# Altium schematic sheet lcd.SchDoc(lcd)
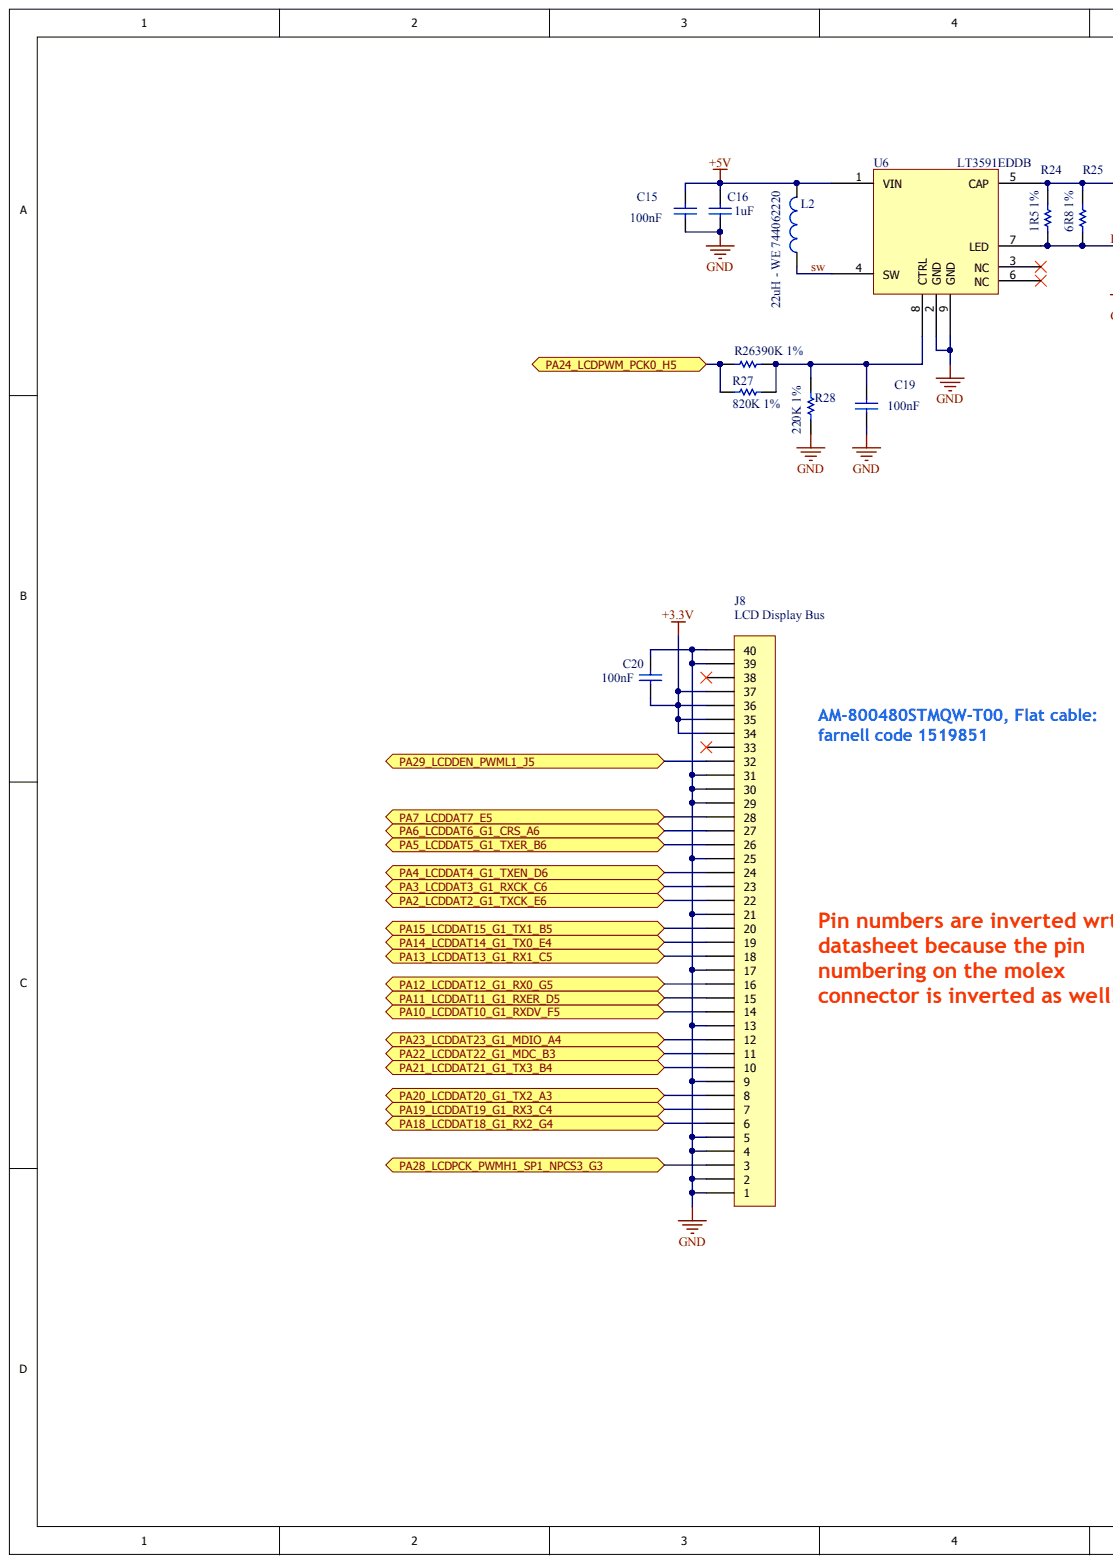
[diagram p0: lcd.SchDoc, left]
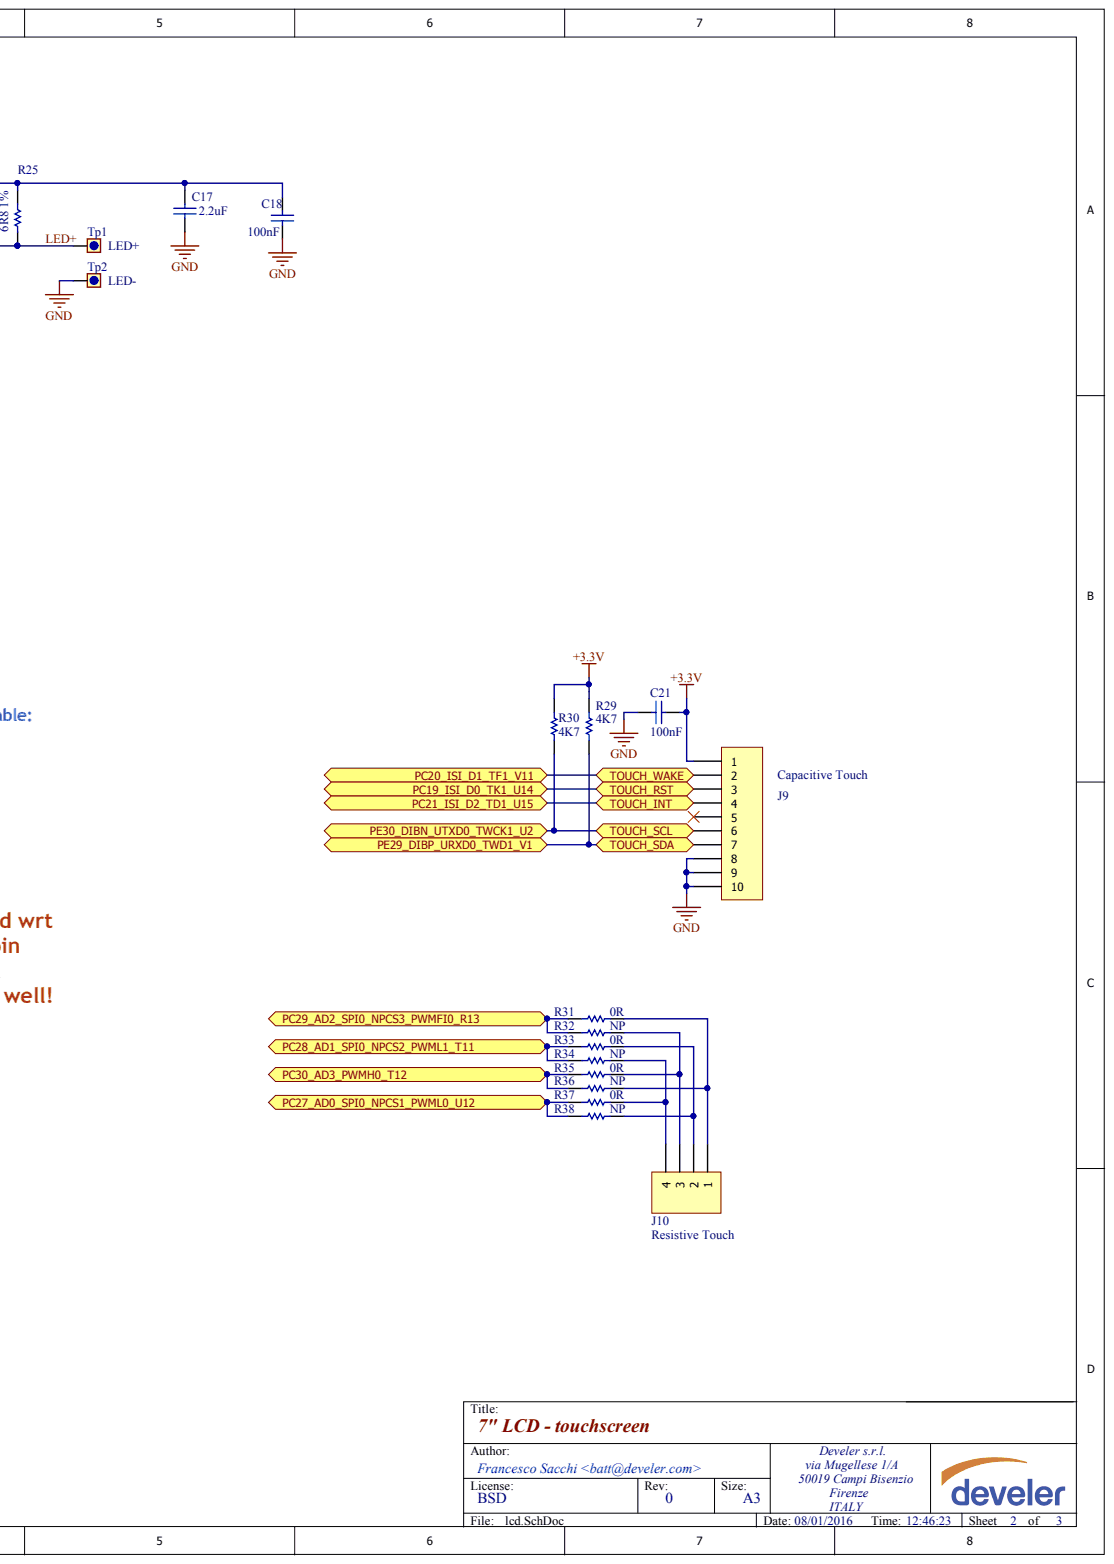
[diagram p1: lcd.SchDoc, right]

## Parts
C15  100nF  [0603_[1608]]
C16  1uF  [0603_[1608]]
C17  2.2uF  [1210_[3225]]
C18  100nF  [0603_[1608]]
C19  100nF  [0603_[1608]]
C20  100nF  [0603_[1608]]
C21  100nF  [0603_[1608]]
J8  LCD Display Bus  [fcc-zif_40pin_0.5mm]
J9  Capacitive Touch  [fcc-zif_10pin_0.5mm]
J10  Resistive Touch  [fcc-zif_4pin_1mm]
L2  22uH - WE 744062220  [WE-TPC-6823-X]
R24  1R5 1%  [0603_[1608]]
R25  6R8 1%  [0603_[1608]]
R26  390K 1%  [0603_[1608]]
R27  820K 1%  [0603_[1608]]
R28  220K 1%  [0603_[1608]]
R29  4K7  [0603_[1608]]
R30  4K7  [0603_[1608]]
R31  0R  [0603_[1608]]
R32  NP  [0603_[1608]]
R33  0R  [0603_[1608]]
R34  NP  [0603_[1608]]
R35  0R  [0603_[1608]]
R36  NP  [0603_[1608]]
R37  0R  [0603_[1608]]
R38  NP  [0603_[1608]]
Tp1  LED+  [TEST_POINT_SMD_R5x3MM]  (no BOM)
Tp2  LED-  [TEST_POINT_SMD_R5x3MM]  (no BOM)
U6  LT3591EDDB  [PQFN50P300X200X75_HS-9L]

## Ports
PA2_LCDDAT2_G1_TXCK_E6, PA3_LCDDAT3_G1_RXCK_C6, PA4_LCDDAT4_G1_TXEN_D6, PA5_LCDDAT5_G1_TXER_B6, PA6_LCDDAT6_G1_CRS_A6, PA7_LCDDAT7_E5, PA10_LCDDAT10_G1_RXDV_F5, PA11_LCDDAT11_G1_RXER_D5, PA12_LCDDAT12_G1_RX0_G5, PA13_LCDDAT13_G1_RX1_C5, PA14_LCDDAT14_G1_TX0_E4, PA15_LCDDAT15_G1_TX1_B5, PA18_LCDDAT18_G1_RX2_G4, PA19_LCDDAT19_G1_RX3_C4, PA20_LCDDAT20_G1_TX2_A3, PA21_LCDDAT21_G1_TX3_B4, PA22_LCDDAT22_G1_MDC_B3, PA23_LCDDAT23_G1_MDIO_A4, PA24_LCDPWM_PCK0_H5, PA28_LCDPCK_PWMH1_SP1_NPCS3_G3, PA29_LCDDEN_PWML1_J5, PC19_ISI_D0_TK1_U14, PC20_ISI_D1_TF1_V11, PC21_ISI_D2_TD1_U15, PC27_AD0_SPI0_NPCS1_PWML0_U12, PC28_AD1_SPI0_NPCS2_PWML1_T11, PC29_AD2_SPI0_NPCS3_PWMFI0_R13, PC30_AD3_PWMH0_T12, PE29_DIBP_URXD0_TWD1_V1, PE30_DIBN_UTXD0_TWCK1_U2, TOUCH_INT, TOUCH_RST, TOUCH_SCL, TOUCH_SDA, TOUCH_WAKE

## Nets
+3.3V: C20.1 C21.1 J8.34 J8.35 J8.36 J8.37 J9.1 R29.1 R30.1
+5V: C15.2 C16.2 L2.2 U6.1(VIN)
GND: C15.1 C16.1 C17.1 C18.1 C19.1 C20.2 C21.2 J8.1 J8.2 J8.4 J8.5 J8.9 J8.13 J8.17 J8.21 J8.25 J8.29 J8.30 J8.31 J8.39 J8.40 J9.8 J9.9 J9.10 R28.2 Tp2.1 U6.2(GND) U6.9(GND)
LED+: R24.1 R25.1 Tp1.1 U6.7(LED)
PA2_LCDDAT2_G1_TXCK_E6: J8.22 ; port PA2_LCDDAT2_G1_TXCK_E6
PA3_LCDDAT3_G1_RXCK_C6: J8.23 ; port PA3_LCDDAT3_G1_RXCK_C6
PA4_LCDDAT4_G1_TXEN_D6: J8.24 ; port PA4_LCDDAT4_G1_TXEN_D6
PA5_LCDDAT5_G1_TXER_B6: J8.26 ; port PA5_LCDDAT5_G1_TXER_B6
PA6_LCDDAT6_G1_CRS_A6: J8.27 ; port PA6_LCDDAT6_G1_CRS_A6
PA7_LCDDAT7_E5: J8.28 ; port PA7_LCDDAT7_E5
PA10_LCDDAT10_G1_RXDV_F5: J8.14 ; port PA10_LCDDAT10_G1_RXDV_F5
PA11_LCDDAT11_G1_RXER_D5: J8.15 ; port PA11_LCDDAT11_G1_RXER_D5
PA12_LCDDAT12_G1_RX0_G5: J8.16 ; port PA12_LCDDAT12_G1_RX0_G5
PA13_LCDDAT13_G1_RX1_C5: J8.18 ; port PA13_LCDDAT13_G1_RX1_C5
PA14_LCDDAT14_G1_TX0_E4: J8.19 ; port PA14_LCDDAT14_G1_TX0_E4
PA15_LCDDAT15_G1_TX1_B5: J8.20 ; port PA15_LCDDAT15_G1_TX1_B5
PA18_LCDDAT18_G1_RX2_G4: J8.6 ; port PA18_LCDDAT18_G1_RX2_G4
PA19_LCDDAT19_G1_RX3_C4: J8.7 ; port PA19_LCDDAT19_G1_RX3_C4
PA20_LCDDAT20_G1_TX2_A3: J8.8 ; port PA20_LCDDAT20_G1_TX2_A3
PA21_LCDDAT21_G1_TX3_B4: J8.10 ; port PA21_LCDDAT21_G1_TX3_B4
PA22_LCDDAT22_G1_MDC_B3: J8.11 ; port PA22_LCDDAT22_G1_MDC_B3
PA23_LCDDAT23_G1_MDIO_A4: J8.12 ; port PA23_LCDDAT23_G1_MDIO_A4
PA24_LCDPWM_PCK0_H5: R26.2 R27.1 ; port PA24_LCDPWM_PCK0_H5
PA28_LCDPCK_PWMH1_SP1_NPCS3_G3: J8.3 ; port PA28_LCDPCK_PWMH1_SP1_NPCS3_G3
PA29_LCDDEN_PWML1_J5: J8.32 ; port PA29_LCDDEN_PWML1_J5
PC19_ISI_D0_TK1_U14: J9.3 ; port PC19_ISI_D0_TK1_U14, port TOUCH_RST
PC20_ISI_D1_TF1_V11: J9.2 ; port PC20_ISI_D1_TF1_V11, port TOUCH_WAKE
PC21_ISI_D2_TD1_U15: J9.4 ; port PC21_ISI_D2_TD1_U15, port TOUCH_INT
PC27_AD0_SPI0_NPCS1_PWML0_U12: R37.2 R38.2 ; port PC27_AD0_SPI0_NPCS1_PWML0_U12
PC28_AD1_SPI0_NPCS2_PWML1_T11: R33.2 R34.2 ; port PC28_AD1_SPI0_NPCS2_PWML1_T11
PC29_AD2_SPI0_NPCS3_PWMFI0_R13: R31.2 R32.2 ; port PC29_AD2_SPI0_NPCS3_PWMFI0_R13
PC30_AD3_PWMH0_T12: R35.2 R36.2 ; port PC30_AD3_PWMH0_T12
PE29_DIBP_URXD0_TWD1_V1: J9.7 R29.2 ; port PE29_DIBP_URXD0_TWD1_V1, port TOUCH_SDA
PE30_DIBN_UTXD0_TWCK1_U2: J9.6 R30.2 ; port PE30_DIBN_UTXD0_TWCK1_U2, port TOUCH_SCL
SW: L2.1 U6.4(SW)
NetC17_2: C17.2 C18.2 R24.2 R25.2 U6.5(CAP)
NetC19_2: C19.2 R26.1 R27.2 R28.1 U6.8(CTRL)
NetJ10_1: J10.1 R31.1 R36.1
NetJ10_2: J10.2 R33.1 R38.1
NetJ10_3: J10.3 R32.1 R35.1
NetJ10_4: J10.4 R34.1 R37.1
N00228:

Not connected: J8 33 38; J9 5; U6 3(NC) 6(NC)

## Library data of the parts
C15 C18-C21 = Cap: Ceramic X7R 50V; Value: 10nF; Component Kind: Standard; Published: 8-Jun-2000; Revision: July-2002: Re-released for DXP Platform.; Signal Integrity: Cap; Simulation: CAP; Library Name: DEVELER_Library.SchLib; Publisher: Altium Limited
C16 = Cap: Ceramic X7R, X5R 16V; Value: 10nF; Component Kind: Standard; Published: 8-Jun-2000; Revision: July-2002: Re-released for DXP Platform.; Signal Integrity: Cap; Simulation: CAP; Library Name: DEVELER_Library.SchLib; Publisher: Altium Limited
C17 = Cap: Ceramic X7R, X5R 50V; Value: 10nF; Component Kind: Standard; Published: 8-Jun-2000; Revision: July-2002: Re-released for DXP Platform.; Signal Integrity: Cap; Simulation: CAP; Library Name: DEVELER_Library.SchLib; Publisher: Altium Limited
J8 = Header 40: 40-pin, 0.5mm, SMT, ZIF, Horizontal, Bottom Contact (Molex 54132-4062; WE 68714014522); Component Kind: Standard; LatestRevisionDate: 17-Jul-2002; LatestRevisionNote: Re-released for DXP Platform.; Signal Integrity: Connector; Library Name: DEVELER_Library.SchLib; Publisher: Altium Limited
J9 = Header 10: 10-pin, 0.5mm, SMT, ZIF, Horizontal, Bottom Contact (Molex 52746-1071; WE 68711014522); Component Kind: Standard; LatestRevisionDate: 17-Jul-2002; LatestRevisionNote: Re-released for DXP Platform.; Library Name: Miscellaneous Connectors.IntLib; Signal Integrity: Connector; Publisher: Altium Limited
J10 = Header 4: 4-pin, 0.5mm, SMT, ZIF, Horizontal, Top Contact (Molex 52207-0433; WE 68610414122); Component Kind: Standard; LatestRevisionDate: 17-Jul-2002; LatestRevisionNote: Re-released for DXP Platform.; Library Name: Miscellaneous Connectors.IntLib; Signal Integrity: Connector; Publisher: Altium Limited
L2 = Inductor: Power Inductor; Component Kind: Standard; Library Name: DEVELER_Library.SchLib
R24 R25 R29 R30 = Res1: Resistor 0.125W; Value: 1K; Component Kind: Standard; Published: 8-Jun-2000; Revision: July-2002: Re-released for DXP Platform.; Signal Integrity: Res; Simulation: RESISTOR; Library Name: DEVELER_Library.SchLib; Publisher: Altium Limited
R26-R28 R31-R38 = Res1: Resistor; Value: 1K; Component Kind: Standard; Published: 8-Jun-2000; Revision: July-2002: Re-released for DXP Platform.; Signal Integrity: Res; Simulation: RESISTOR; Library Name: DEVELER_Library.SchLib; Publisher: Altium Limited
Tp1 Tp2 = Test_point: Library Name: DEVELER_Library.SchLib
U6 = LT3591: White LED Driver with Integrated Schottky; Component Kind: Standard; Library Name: DEVELER_Library.SchLib
